# Altium schematic sheet OUT_Solenoid_TPS1HTC30.SchDoc(OUT_Solenoid_TPS1HTC30)
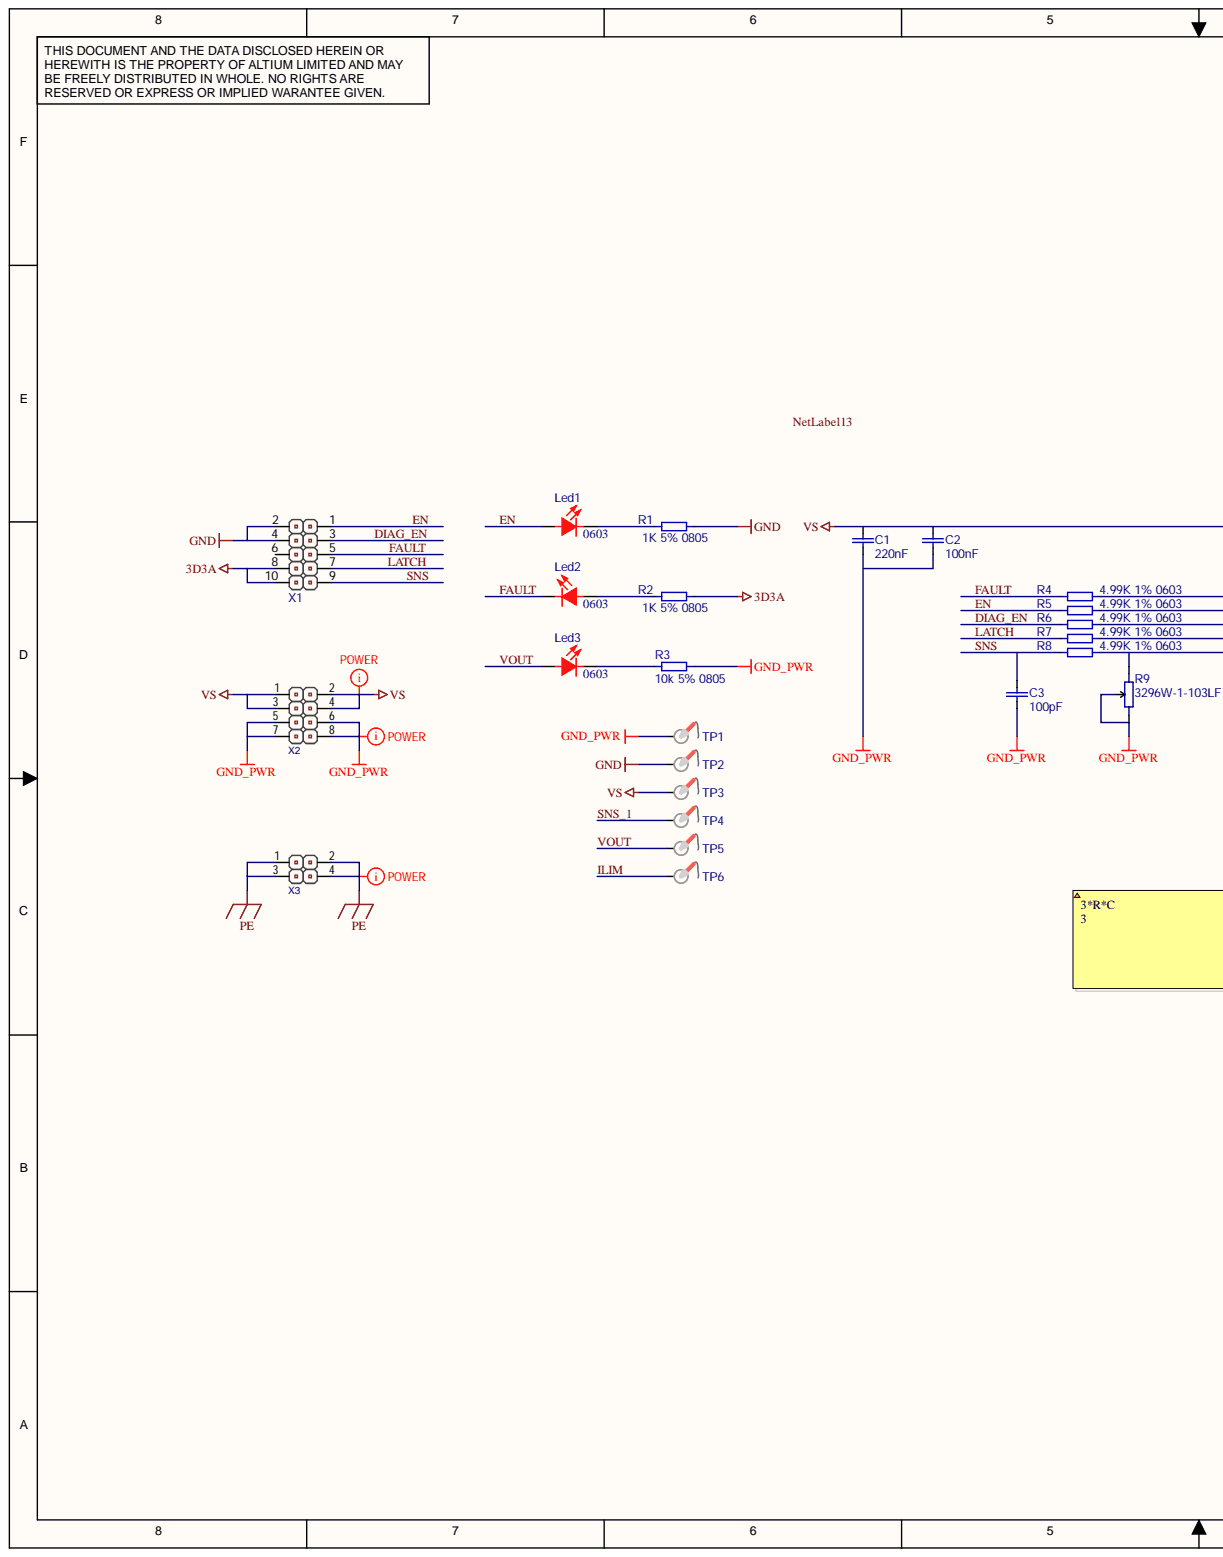
[diagram p0: OUT_Solenoid_TPS1HTC30.SchDoc, left]
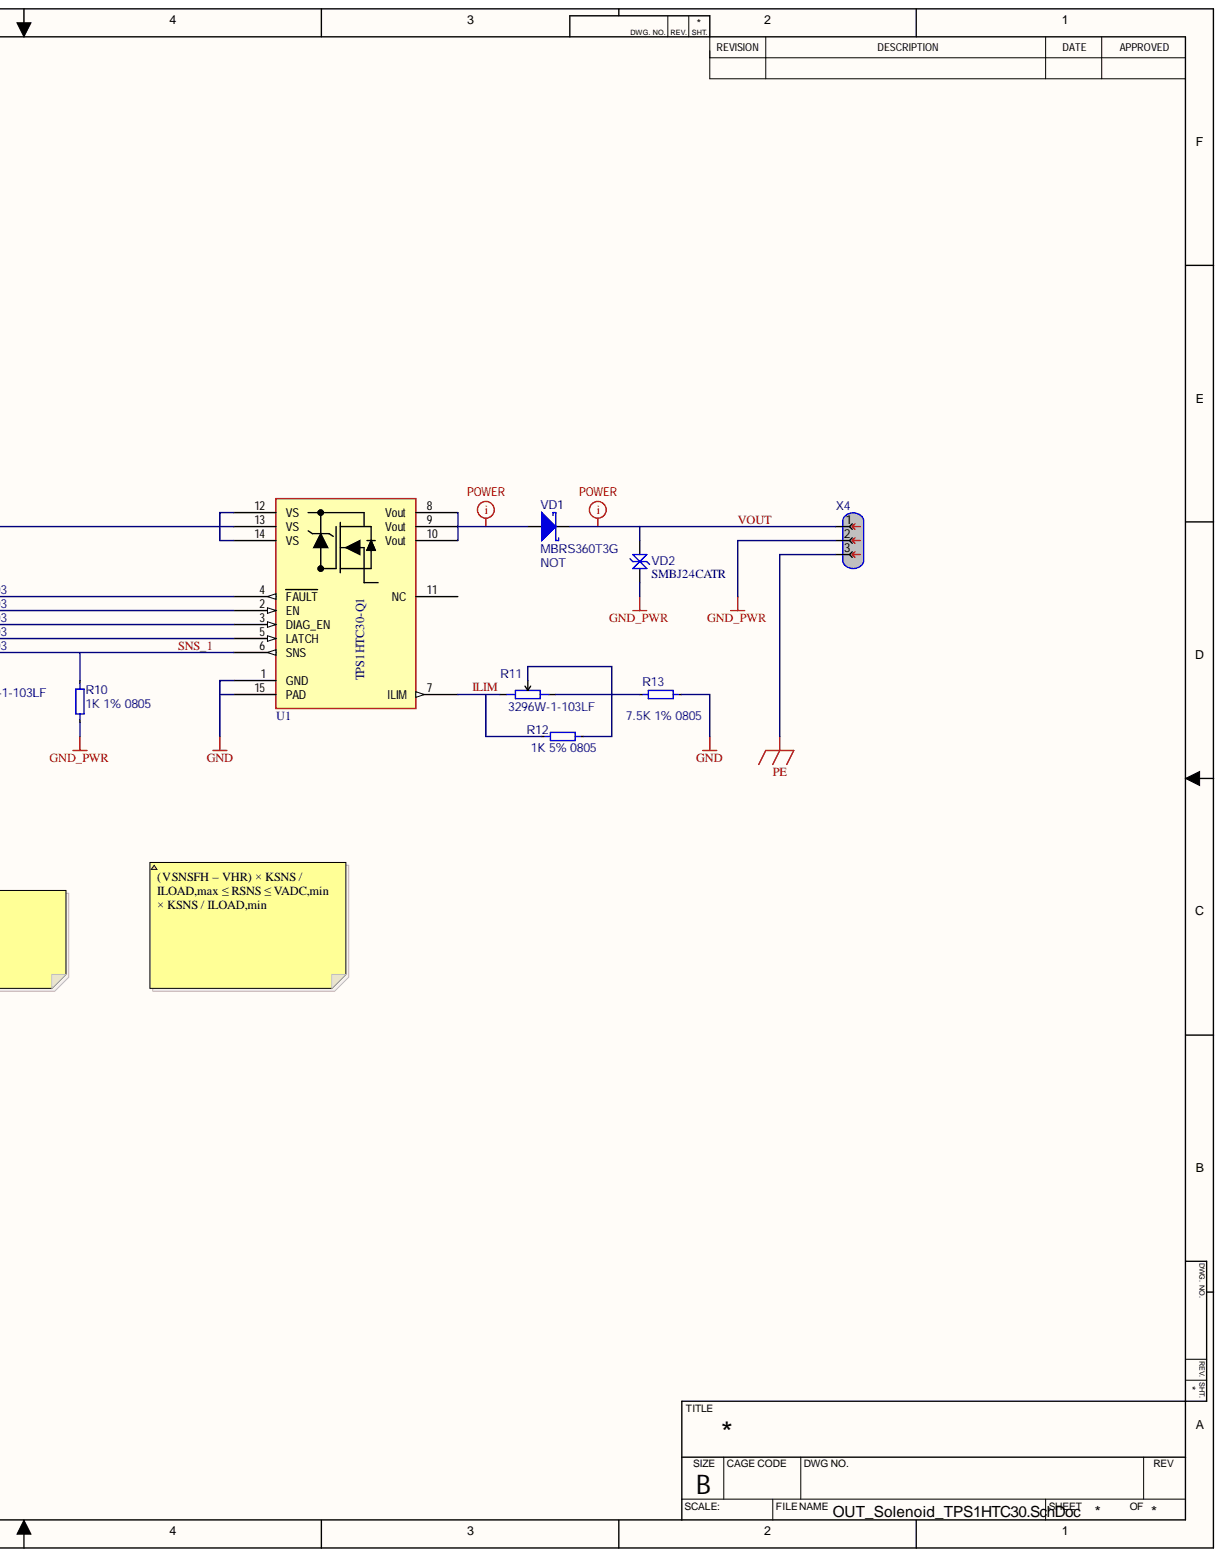
[diagram p1: OUT_Solenoid_TPS1HTC30.SchDoc, right]

## Parts
C1  Capacitance: 220nF  [C_0805]  (hidden comment: 220nF 25V 0805)
C2  Capacitance: 100nF  [C_0805]  (hidden comment: 100nF 25V 0805)
C3  Capacitance: 100pF  [C_0603]  (hidden comment: 100pF 50V 0603)
Led1  EN  Case/Package: 0603  [LED_0603-RED]
Led2  FAULT  Case/Package: 0603  [LED_0603-RED]
Led3  VOUT  Case/Package: 0603  [LED_0603-RED]
R1  1K 5% 0805  Package / Case: 0805  Tolerance: 5%  [R_0805]
R2  1K 5% 0805  Package / Case: 0805  Tolerance: 5%  [R_0805]
R3  10k 5% 0805  Package / Case: 0805  Resistance: 10k  [R_0805]
R4  4.99K 1% 0603  Tolerance: 1%  [R_0603]
R5  4.99K 1% 0603  Tolerance: 1%  [R_0603]
R6  4.99K 1% 0603  Tolerance: 1%  [R_0603]
R7  4.99K 1% 0603  Tolerance: 1%  [R_0603]
R8  4.99K 1% 0603  [R_0603]
R9  3296W-1-103LF  [Bourns 3296 pot]
R10  1K 1% 0805  Tolerance: 1%  [R_0805]
R11  3296W-1-103LF  [Bourns 3296 pot]
R12  1K 5% 0805  Package / Case: 0805  Tolerance: 5%  [R_0805]
R13  7.5K 1% 0805  Package / Case: 0805  Tolerance: 1%  [R_0805]
TP1  GND-P  [TP_1308]  (value not drawn)  (no BOM)
TP2  GND  [TP_1308]  (no BOM)
TP3  VS  [TP_1308]  (no BOM)
TP4  SNS  [TP_1308]  (no BOM)
TP5  OUT  [TP_1308]  (value not drawn)  (no BOM)
TP6  ILIM  [TP_1308]  (value not drawn)  (no BOM)
U1  TPS1HTC30-Q1  [HTSSOP-14-PowerPAD]
VD1  MBRS360T3G  Box_ID: NOT  [SMC (DO214AB)]
VD2  SMBJ24CATR  [SMB]
X1  PLD-10  [PLD-10]  (value not drawn)
X2  PLD-8  [PLD-8]  (value not drawn)
X3  PLD-4  [PLD-4-Footprint-1]  (value not drawn)
X4  15EDGVC-2.5-03P-14-00AH  [15EDGVC-2.5-03P-14-00AH]  (value not drawn)

## Nets
3D3A: R2.2 X1.8 X1.10
GND: R1.2 R13.2 TP2.1 U1.1(GND) U1.15(PAD) X1.2 X1.4
GND_PWR: C1.1 C2.1 C3.1 R3.2 R9.2 R9.3 R10.1 TP1.1 VD2.1 X2.5 X2.6 X2.7 X2.8 X4.2
PE: X3.1 X3.2 X3.3 X3.4 X4.3
VS: C1.2 C2.2 TP3.1 U1.12(VS) U1.13(VS) U1.14(VS) X2.1 X2.2 X2.3 X2.4
DIAG_EN: R6.1 X1.3
EN: Led1.1 R5.1 X1.1
FAULT: Led2.2 R4.1 X1.5
ILIM: R11.1 R12.1 TP6.1 U1.7(ILIM)
LATCH: R7.1 X1.7
SNS: C3.2 R8.1 X1.9
SNS_1: R8.2 R9.1 R10.2 TP4.1 U1.6(SNS)
VOUT: Led3.1 TP5.1 VD1.2 VD2.2 X4.1
NetLed1_2: Led1.2 R1.1
NetLed2_1: Led2.1 R2.1
NetLed3_2: Led3.2 R3.1
NetR4_2: R4.2 U1.4(FAULT)
NetR5_2: R5.2 U1.2(EN)
NetR6_2: R6.2 U1.3(DIAG_EN)
NetR7_2: R7.2 U1.5(LATCH)
NetR11_2: R11.2 R11.3 R12.2 R13.1
NetU1_8: U1.8(Vout) U1.9(Vout) U1.10(Vout) VD1.1
NetLabel13:

Not connected: U1 11(NC); X1 6

## Library data of the parts
C1 = CMP-00963-4: CAP CER 0805 220nF 25V X7R 5%; Box_ID: NOT; Component_Type: Capacitor; Disignator: C?; Sub_Type: Ceramic; Component Kind: Standard; Dielectric: X7R; ID_Company: NOT; Library Name: <Company> Server; Package: 0805; Tolerance: 5%; Voltage Rating: 25V
C2 = CMP-00482-11: CAP CER 0805 0.1uF 25V X7R 5%; Box_ID: NOT; Component_Type: Capacitor; Disignator: C?; Sub_Type: Ceramic; Component Kind: Standard; Dielectric: X7R; ID_Company: NOT; Library Name: <Company> Server; Package: 0805; Tolerance: 5%; Voltage Rating: 25V
C3 = CMP-00895-4: CAP CER 0603 100pF 50V NPO 5%; Box_ID: NOT; Component_Type: Capacitor; Disignator: C?; Sub_Type: Ceramic; Component Kind: Standard; Dielectric: NPO; ID_Company: NOT; Library Name: <Company> Server; Package: 0603; Tolerance: 5%; Voltage Rating: 50V
Led1-Led3 = CMP-007-00036-13: RED; Box_ID: NOT; Colour: RED; Component Kind: Standard; Designator: LED?; ID_Company: NOT; Library Name: <Company> Server; Mounting Technology: SMD; Pins: 2
  Led1: NetName: EN
  Led2: NetName: FAULT
  Led3: NetName: VOUT
R1 R2 R12 = Res_0805-10: RES SMD 0805 1K 5% 50V 0.125W; Box_ID: A4; ID_Company: A067; Power: 125W; Temperature Coefficient: ±25ppm/°C; Component Kind: Standard; Designator: R?; Library Name: <Company> Server; Operating Temperature: -55°C ~ 155°C; Resistance: 1k; Voltage Rating: 50V
R3 = CMP-00726-2: RES 10K OHM 5% 1/8W 0805; Box_ID: NOT; Power: 125mW; Temperature Coefficient: 25; Tolerance: 1%; Voltage Rating: 50V; Component Kind: Standard; ID_Company: NOT; Library Name: <Company> Server
R4-R8 = CMP-00192-8: RES SMD 0603 4.99K 1% 50V 0.1W; Box_ID: NOT; Power: 100mW; Resistance: 4.7k; Temperature Coefficient: ±25ppm/°C; Component Kind: Standard; Designator: R?; ID_Company: NOT; Library Name: <Company> Server; Operating Temperature: -55°C ~ 155°C; Package / Case: 0603; Voltage Rating: 50V
  R8: Tolerance: 1%
R9 R11 = CMP-01280-1: 10K; Box_ID: NOT; Power: 500mW; Tolerance: 10%; Voltage Rating: 50V; Component Kind: Standard; ID_Company: NOT; Library Name: <Company> Server; Package / Case: 3296; Resistance: 10k
R10 = CMP-00483-2: RES SMD 0805 1K 1% 50V 0.125W; Box_ID: NOT; Power: 125W; Temperature Coefficient: ±25ppm/°C; Component Kind: Standard; ID_Company: NOT; Library Name: <Company> Server; Operating Temperature: -55°C ~ 155°C; Package / Case: 0805; Resistance: 1k; Voltage Rating: 50V
R13 = CMP-01197-1: RES SMD 0805 7.5K 1% 50V 0.125W; Box_ID: NOT; Power: 125W; Temperature Coefficient: ±25ppm/°C; Component Kind: Standard; Designator: R?; ID_Company: NOT; Library Name: <Company> Server; Operating Temperature: -55°C ~ 155°C; Resistance: 7.5k; Voltage Rating: 50V
TP1-TP6 = CMP-002-00077-3: 1.3mmx0.8mm; Box_ID: NC; Mounting Technology: NC; Pins number: 1; Sub_Type: TestPoint; Connector Type: NC; ID_Company: NC; Library Name: <Company> Server; Manufacturer Part Number: NC; Pins: NC
U1 = CMP-019-00113-1: Power Smart Switch/Driver 1:1 N-Channel 6A,60-V TOLERANT, 14-HTSSOP; Box_ID: NOT; Disignator: U?; ID_Company: Texas Instruments; Max Output Voltage: 60V; Max Supply Voltage: 5.5V; Min Output Voltage: 6V; Min Supply Voltage: -1V; Case/Package: 14-HTSSOP; Component Kind: Standard; Library Name: <Company> Server; Max Output Current: 6A; Output Type: N-Channel; Package / Case: 14-TSSOP (0.173", 4.40mm Width) Exposed Pad; Pins: 15; Type: DC/DC Stepdown
VD1 = CMP-01138-1: DIODE SCHOTTKY 60V 3A SMC; Disignator: VD?; Sub_Type: Shottky; Component Kind: Standard; Component_Type: Diode; Current: 3A; ID_Company: NOT; Library Name: <Company> Server; Manufacturer Part Number: MBRS360T3G; Voltage: 60V
VD2 = CMP-01013-2: TVS DIODE 24V 38.9V SMB; Box_ID: NOT; Current: 1kA; Disignator: VD?; Sub_Type: unidirectional; Component Kind: Standard; Component_Type: TVS; ID_Company: NOT; Library Name: <Company> Server; Manufacturer Part Number: NOT; Voltage: 24V
X1 = CMP-002-00079-2: PLD-10, 2.54 mm; Box_ID: NOT; Component_Type: Connectors; Pins number: 10; Sub_Type: PIN HEDER; Component Kind: Standard; ID_Company: NOT; Library Name: <Company> Server; Manufacturer Part Number: PLD -10; Mounting Technology: dip
X2 = CMP-002-00043-5: PLD-8, 2.54 mm; Box_ID: NOT; Component_Type: Connectors; Pins number: 8; Sub_Type: PIN HEDER; Component Kind: Standard; ID_Company: NOT; Library Name: <Company> Server; Manufacturer Part Number: PLD-8; Mounting Technology: dip
X3 = CMP-002-00223-1: PLD-4, 2.54mm; Box_ID: NOT; Pins number: 4; Sub_Type: PIN HEDER; Component Kind: Standard; ID_Company: NOT; Library Name: <Company> Server; Manufacturer Part Number: PLD-4; Mounting Technology: dip
X4 = CMP-002-00171-1: 3 PIN 2.5mm Прямой; Box_ID: NOT; Component_Type: Conectors; Connector Type: SOCKET; Pins number: 3; Pitch: 2.5; Sub_Type: NC; Component Kind: Standard; ID_Company: NOT; Library Name: <Company> Server; Manufacturer Part Number: 15EDGVC-2.5-03P-14-00AH; Mount: Screw; Mounting Technology: DIP
